# Altium schematic sheet power.SchDoc
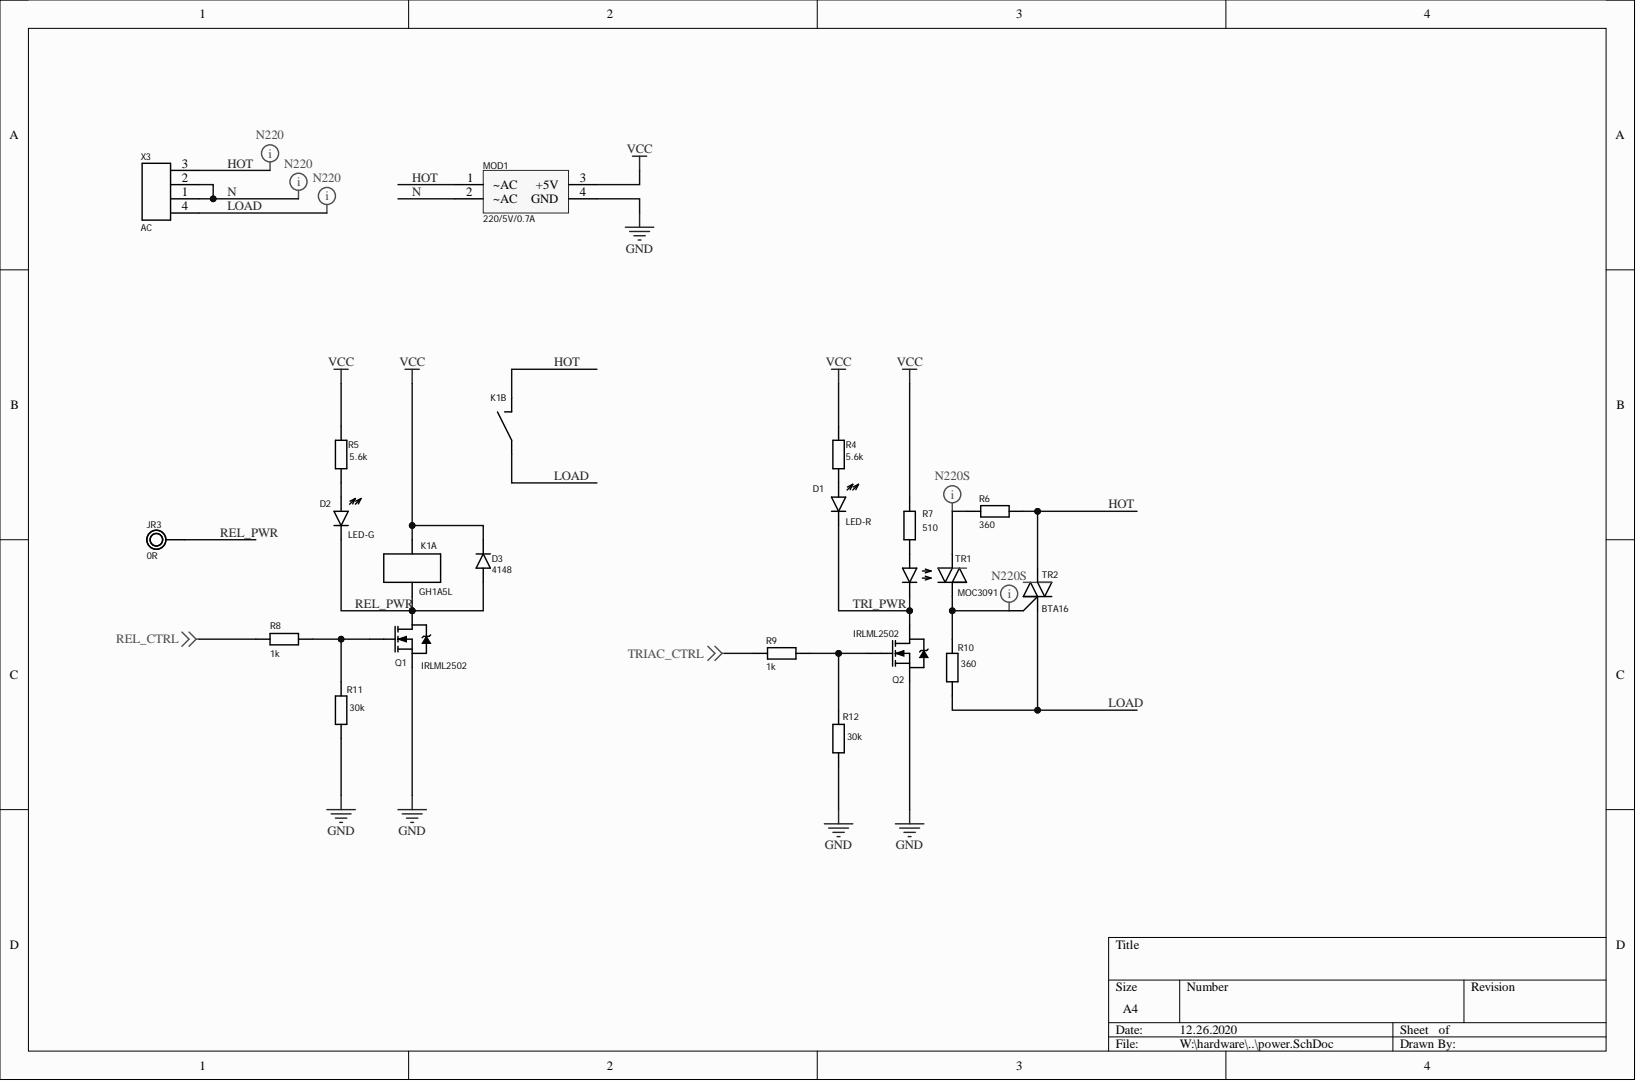
[diagram p0: power.SchDoc]

## Parts
D1  LED-R  [LED-0603]
D2  LED-G  [LED-0603]
D3  4148  [D-SOD-123F]
JR3  0R  [J-0805W]
K1  GH1A5L  [GH1A12L]  (parts A,B)
MOD1  220/5V/0.7A  [POWER_SUPPLY_220/5]
Q1  IRLML2502  [SOT-23-GSD]
Q2  IRLML2502  [SOT-23-GSD]
R4  5.6k  [R-0603]
R5  5.6k  [R-0603]
R6  360  [R-1206]
R7  510  [R-0805]
R8  1k  [R-0805]
R9  1k  [R-0805]
R10  360  [R-1206]
R11  30k  [R-0805]
R12  30k  [R-0805]
TR1  MOC3091  [SOP-6]
TR2  BTA16  [TO220]
X3  AC  [KF-7.62-4P]

## Nets
GND: MOD1.4(GND) Q1.S Q2.S R11.1 R12.1
REL_CTRL: R8.2
TRIAC_CTRL: R9.2
VCC: D3.C K1.C2 MOD1.3(+5V) R4.2 R5.2 R7.2
HOT: K1.K1 MOD1.1(~AC) R6.2 TR2.AH X3.3
LOAD: K1.K2 R10.1 TR2.AN X3.4
N: MOD1.2(~AC) X3.1 X3.2
REL_PWR: D2.C D3.A JR3.1 K1.C1 Q1.D
TRI_PWR: D1.C Q2.D TR1.C
NetD1_A: D1.A R4.1
NetD2_A: D2.A R5.1
NetQ1_G: Q1.G R8.1 R11.2
NetQ2_G: Q2.G R9.1 R12.2
NetR6_1: R6.1 TR1.AH
NetR7_1: R7.1 TR1.LA
NetR10_2: R10.2 TR1.AN TR2.G

## Library data of the parts
D1 D2 = LED: Component Kind: Standard; Library Name: all-in-one.IntLib
  D1: value: LED-R
  D2: value: LED-G
D3 = DIODE: Component Kind: Standard; Library Name: all-in-one.IntLib; value: 4148
JR3 = JAMPER-RES: Component Kind: Standard; Library Name: all-in-one.IntLib; value: 0R
K1 = RELAY-1: Component Kind: Standard; Library Name: all-in-one.IntLib; value: GH1A5L
MOD1 = POWER_SUPPLY_220/5V: Component Kind: Standard; Library Name: all-in-one.IntLib; value: 220/5V/0.7A
Q1 Q2 = MOSFET-N: Component Kind: Standard; Description: MOSFET-N; Library Name: all-in-one.IntLib; value: IRLML2502
R4-R12 = RESISTOR: Component Kind: Standard; Library Name: all-in-one.IntLib
  R4 R5: value: 5.6k
  R6 R10: value: 360
  R7: value: 510
  R8 R9: value: 1k
  R11 R12: value: 30k
TR1 = OPT_TRIAC_MOC3091: Component Kind: Standard; Library Name: all-in-one.IntLib; value: MOC3091
TR2 = TRIAC: Component Kind: Standard; Library Name: all-in-one.IntLib
X3 = PINHEAD-4x1: Component Kind: Standard; Library Name: all-in-one.IntLib
